# Altium schematic sheet Boosta_Defender.SchDoc
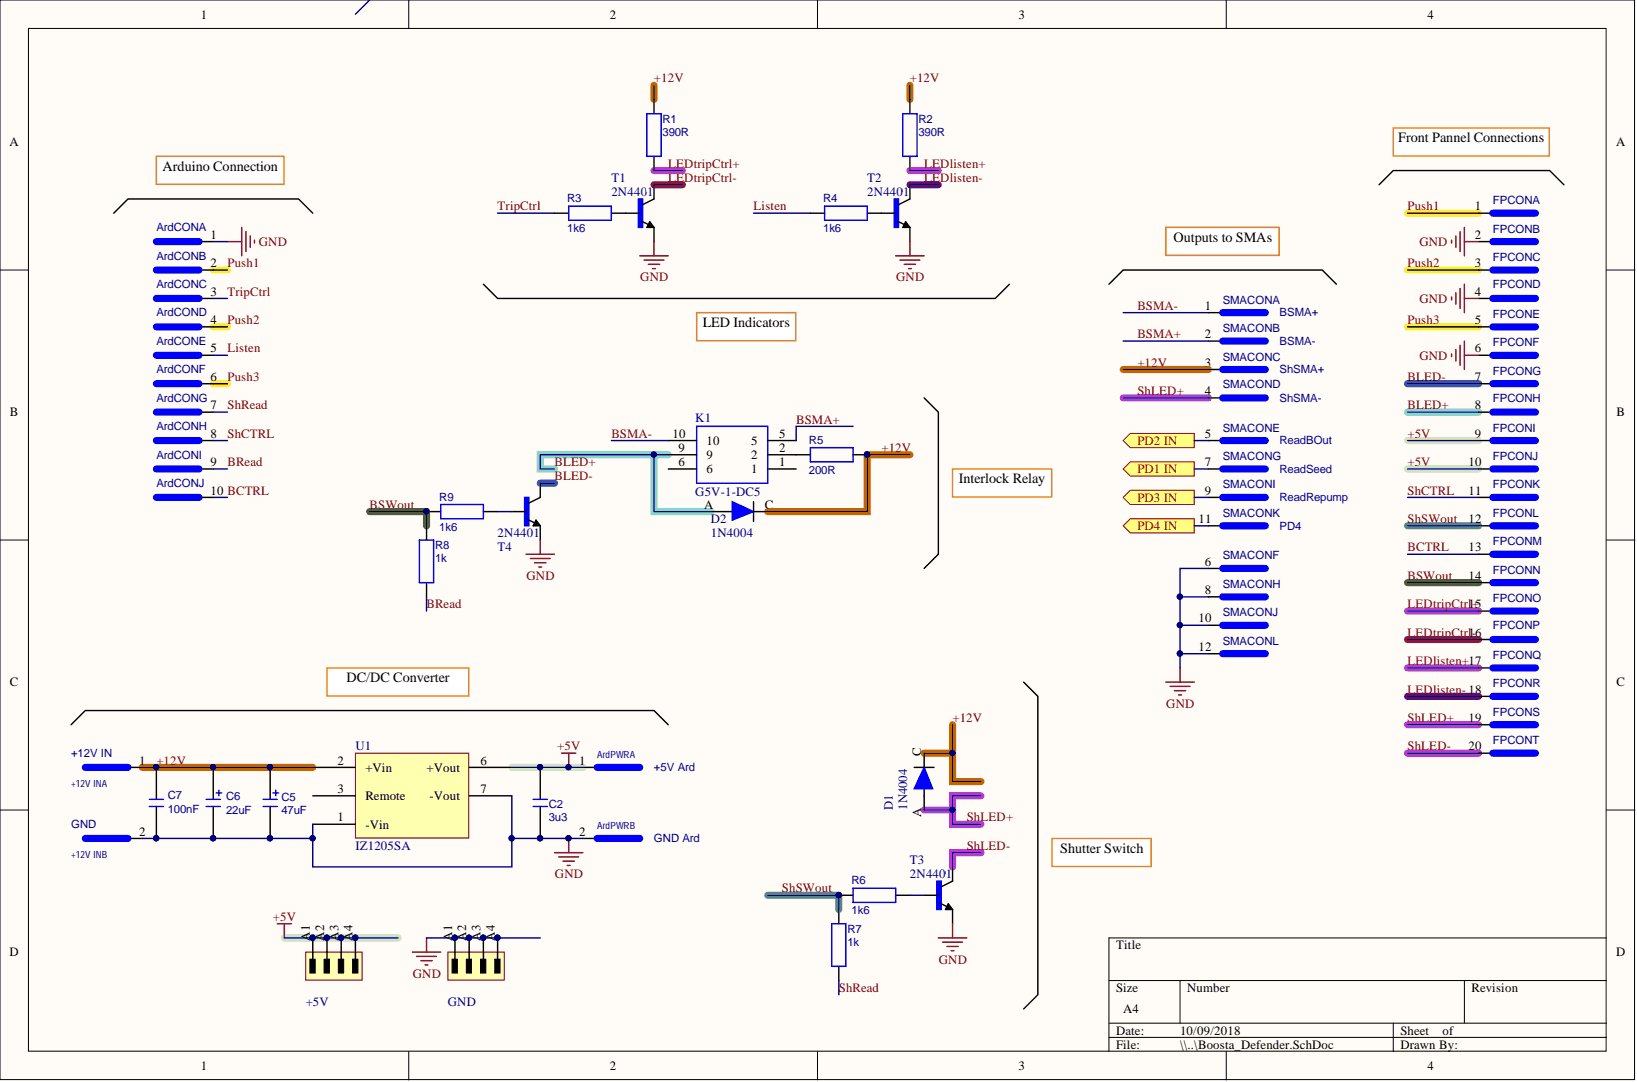
[diagram p0: Boosta_Defender.SchDoc]

## Parts
+5V  Pins 4  [Pins 4]  (value not drawn)
+12V_IN  +12V IN  [22-27-2021]  (parts A,B)
ArdCON  (no value)  [T821110A1S100CEU]  (parts A,B,C,D,E,F,G,H,I,J)
ArdPWR  +5V Ard  [22-27-2021]  (parts A,B)
C2  value 3u3  [CAPACITOR SMD 0805]  (hidden comment: Capacitor SMD 0805)
C5  value 47uF  [NHG_Dia6.3mm_Len11.2mm_Pitch100mils]  (hidden comment: Capacitor Electrolytic NHG)
C6  value 22uF  [T491_B]  (hidden comment: T491)
C7  value 100nF  [CAPACITOR SMD 0805]  (hidden comment: Capacitor SMD 0805)
D1  1N4004  [DIOAD1060W80L520D270_1N4007(Primary)]
D2  1N4004  [DIOAD1060W80L520D270_1N4007(Primary)]
FPCON  (no value)  [RibbonHeader20way]  (parts A,B,C,D,E,F,G,H,I,J,K,L,M,N,O,P,Q,R,S,T)
GND  Pins 4  [Pins 4]  (value not drawn)
K1  G5V-1-DC5  [G5V-1]
R1  value 390R  [RESISTOR SMD 0805]  (hidden comment: Resistor SMD 0805)
R2  value 390R  [RESISTOR SMD 0805]  (hidden comment: Resistor SMD 0805)
R3  value 1k6  [RESISTOR SMD 0805]  (hidden comment: Resistor SMD 0805)
R4  value 1k6  [RESISTOR SMD 0805]  (hidden comment: Resistor SMD 0805)
R5  value 200R  [RESISTOR SMD 0805]  (hidden comment: Resistor SMD 0805)
R6  value 1k6  [RESISTOR SMD 0805]  (hidden comment: Resistor SMD 0805)
R7  value 1k  [RESISTOR SMD 0805]  (hidden comment: Resistor SMD 0805)
R8  value 1k  [RESISTOR SMD 0805]  (hidden comment: Resistor SMD 0805)
R9  value 1k6  [RESISTOR SMD 0805]  (hidden comment: Resistor SMD 0805)
SMACON  BSMA+  [RibbonHeader12way]  (parts A,B,C,D,E,F,G,H,I,J,K,L)
T1  2N4401  [TO92-CBE_2N4401(Primary)]
T2  2N4401  [TO92-CBE_2N4401(Primary)]
T3  2N4401  [TO92-CBE_2N4401(Primary)]
T4  2N4401  [TO92-CBE_2N4401(Primary)]
U1  IZ1205SA  [TMR 6]

## Ports
PD1 IN, PD2 IN, PD3 IN, PD4 IN

## Nets
GND: +12V_IN.2 ArdCON.1 ArdPWR.2 C2.1 C5.2 C6.2 C7.1 FPCON.2 FPCON.4 FPCON.6 GND.A1 GND.A2 GND.A3 GND.A4 SMACON.6 SMACON.8 SMACON.10 SMACON.12 T1.E T2.E T3.E T4.E U1.1(-Vin) U1.7(-Vout)
+5V: +5V.A1 +5V.A2 +5V.A3 +5V.A4 ArdPWR.1 C2.2 FPCON.9 FPCON.10 U1.6(+Vout)
+12V: +12V_IN.1 C5.1 C6.1 C7.2 D1.C D2.C R1.2 R2.2 R5.1 SMACON.3 U1.2(+Vin)
BCTRL: ArdCON.10 FPCON.13
BLED+: D2.A FPCON.8 K1.9
BLED-: FPCON.7 T4.C
BRead: ArdCON.9 R8.1
BSMA+: K1.5 SMACON.2
BSMA-: K1.10 SMACON.1
BSWout: FPCON.14 R8.2 R9.1
LEDlisten+: FPCON.17 R2.1
LEDlisten-: FPCON.18 T2.C
LEDtripCtrl+: FPCON.15 R1.1
LEDtripCtrl-: FPCON.16 T1.C
Listen: ArdCON.5 R4.1
PD1 IN: SMACON.7 ; port PD1 IN
PD2 IN: SMACON.5 ; port PD2 IN
PD3 IN: SMACON.9 ; port PD3 IN
PD4 IN: SMACON.11 ; port PD4 IN
Push1: ArdCON.2 FPCON.1
Push2: ArdCON.4 FPCON.3
Push3: ArdCON.6 FPCON.5
ShCTRL: ArdCON.8 FPCON.11
ShLED+: D1.A FPCON.19 SMACON.4
ShLED-: FPCON.20 T3.C
ShRead: ArdCON.7 R7.1
ShSWout: FPCON.12 R6.1 R7.2
TripCtrl: ArdCON.3 R3.1
NetK1_2: K1.2 R5.2
NetR3_2: R3.2 T1.B
NetR4_2: R4.2 T2.B
NetR6_2: R6.2 T3.B
NetR9_2: R9.2 T4.B

Not connected: K1 1 6; U1 3(Remote)

## Library data of the parts
+5V GND = Pins 4: OMNIMATE Signal - series BL/SL 5.08; Construction_type: Male header; Contact_material: Cu-Leg; Contact_surface: tinned; DXF: SL_5.08HC_04_180B_3.2SN_OR_BX_1147360000.DXF; ID1: SL_5_08HC_180B_04__3_2_OR_BX; Insulating_material: PA GF; Mounting_onto_the_PCB: Solder connection; No_of_poles: 4; Outgoing_elbow: 180°; PADS_Symbol: Header_Male_04_1x04; Pitch_inch: 0,2 inch; Pitch_mm: 5,08 mm; Rated_current_use_group_B_UL_1059: 16 A; Rated_voltage_for_surge_voltage_class_pollution_degree_II_2: 400 V; Rated_voltage_use_group_B_UL_1059: 300 V; Short_text_for_material: SL 5.08HC/04/180B 3.2SN OR BX; Side_termination_characteristic: Dovetails for fixing blocks; Solder_pin_length_mm: 3,2 mm; UL_94_flammability_rating: V-0; Weidmueller_Order_No: 1147360000; Colour: Orange; Component Kind: Standard; ComponentLink1Description: Weidmueller Product Catalogue -> SL 5.08HC/04/180B; ComponentLink1Url: http://catalog.weidmueller.com/catalog/Start.do?localeId=en&ObjectID=1147360000; Library Name: TB_Connectors.SchLib; Packaging: Box; Qty: 100 PCS; ReleaseDate: 15.08.2014; Revision: V1
+12V_IN ArdPWR = 22-27-2021: Component Kind: Standard; Description: 22-27-2021
  +12V_IN: Library Name: Molex Connector.IntLib
  ArdPWR: Library Name: Molex Connector.SchLib
ArdCON = T821110A1S100CEU: AMPHENOL T821110A1S100CEU Wire-To-Board Connector, Vertical, 2.54 mm, 10 Contacts, Header, T821 Series, Through Hole, 2 Rows; Component Kind: Standard; Library Name: TB_Connectors.IntLib
C2 C7 = Capacitor SMD 0805: Ceramic chip capacitor 0805; Component Kind: Standard; Library Name: TB_Capacitors.IntLib
C5 = Capacitor Electrolytic NHG: Radial lead type aluminium electrolytic capacitor NHG; Component Kind: Standard; Library Name: TB_Capacitors.IntLib
D1 D2 = 1N4007: Diode, Standard Recovery, 1a, 1kv, Do-41, Full Reel; MF: Diodes; MP: 1N4007-T; Availability: Good; Component Kind: Standard; Library Name: 1N4007.SchLib; Package: DO-41 Multicomp; Price: 0.01 USD; SnapEDA_Link: https://www.snapeda.com/parts/1N4007-T/Diodes%20Inc./view-part/?ref=snap
FPCON = RibbonHeader20way: AMPHENOL T821116A1S100CEU Wire-To-Board Connector, Vertical, 2.54 mm, 20 Contacts, Header, T821 Series, Through Hole, 2 Rows; Component Kind: Standard; Library Name: TB_Connectors.SchLib
K1 = G5V-1-DC5: PCB Relay, SPDT, 1A SPDT PCB Mount Non-Latching Relay PC Pin, 1 A, 5V dc; Manufacturer_Name: Omron Electronics; Allied_Number: 70175801; Allied Price/Stock: https://www.alliedelec.com/omron-electronic-components-g5v-1-dc5/70175801/; Arrow Part Number: G5V-1-DC5; Arrow Price/Stock: http://uk.rs-online.com/web/p/products/8411420; Component Kind: Standard; Datasheet Link: http://docs-asia.electrocomponents.com/webdocs/14b2/0900766b814b2cde.pdf; Library Name: G5V-1-DC5.SchLib; Manufacturer_Part_Number: G5V-1-DC5; RS Part Number: 8411420; RS Price/Stock: http://uk.rs-online.com/web/p/products/8411420
R1-R9 = Resistor SMD 0805: Resistor, SMD, 0805; Component Kind: Standard; Library Name: TB_Resistors.IntLib
SMACON = RibbonHeader12way: AMPHENOL T821112A1S100CEU Wire-To-Board Connector, Vertical, 2.54 mm, 12 Contacts, Header, T821 Series, Through Hole, 2 Rows; Component Kind: Standard; Library Name: TB_Connectors.SchLib
T1-T4 = 2N4401: Transistor: NPN; bipolar; 40V; 600mA; 250mW; TO92; MF: Central Semiconductor; Availability: Good; Component Kind: Standard; Library Name: 2N4401.SchLib; MP: 2N4401; Package: TO-92 Diotec; Price: 0.04 USD; SnapEDA_Link: https://www.snapeda.com/parts/2N4401/Central%20Semiconductor/view-part/?ref=snap
U1 = TMR 6-0521: DC-DC converter +-5V, 4.5-9V input; Component Kind: Standard; Library Name: Traco Power Management Voltage Regulator.IntLib
C6 = T491: Component Kind: Standard; Description: T491; Library Name: Kemet Passive.IntLib
